# Altium schematic sheet Counter_Switch.SchDoc
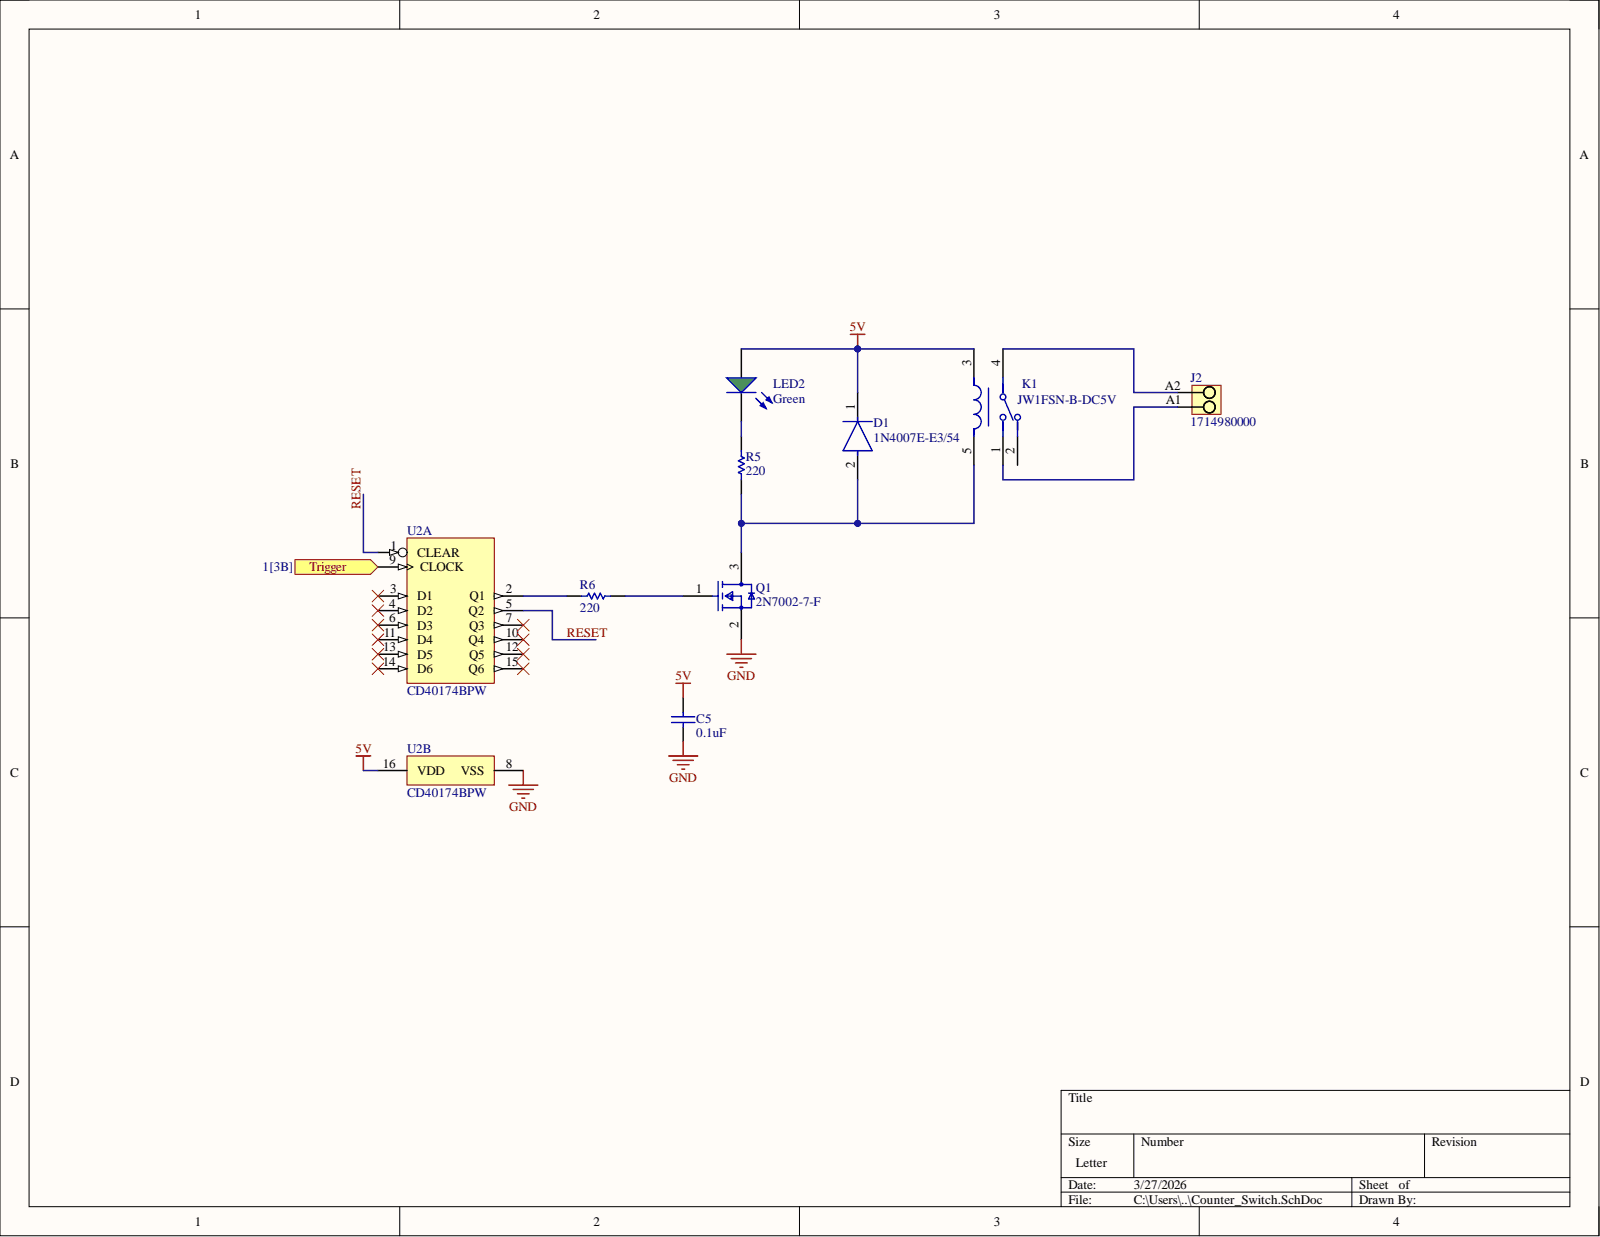
[diagram p0: Counter_Switch.SchDoc]

## Parts
C5  0.1uF  [CAPC2013X145X50ML20T20]
D1  1N4007E-E3/54  [FP-1N4007E-E3-54-MFG]
J2  1714980000  [LM_3_5_135_02]
K1  JW1FSN-B-DC5V  [FP-JW1FSN-B-DC5V-MFG]
LED2  Green  [KING-WP7113SGD_V]
Q1  2N7002-7-F  [FP-SOT23-IPC_A]
R5  220  [RESC2013X60X30NL20T20]
R6  220  [RESC2013X60X30NL20T20]
U2  CD40174BPW  [PW0016A_M]  (parts A,B)

## Ports
Trigger

## Nets
5V: C5.2 D1.1 K1.3 LED2.1 U2.16(VDD)
GND: C5.1 Q1.2 U2.8(VSS)
RESET: U2.1(CLEAR) U2.5(Q2)
Trigger: U2.9(CLOCK) ; port Trigger
NetD1_2: D1.2 K1.5 Q1.3 R5.1
NetJ2_A1: J2.A1 K1.1
NetJ2_A2: J2.A2 K1.4
NetLED2_2: LED2.2 R5.2
NetQ1_1: Q1.1 R6.2
NetR6_1: R6.1 U2.2(Q1)

Not connected: K1 2; U2 3(D1) 4(D2) 6(D3) 7(Q3) 10(Q4) 11(D4) 12(Q5) 13(D5) 14(D6) 15(Q6)

## Library data of the parts
C5 = CAP 0.1uF 10V 0805: Manufacturer: Vitramon; Part Number: VJ0805Y104MXQCW1BC; Component Kind: Standard; Library Name: MDL.SchLib
D1 = Diode General Purpose 1N4007: DIODE GEN PURP 1KV 1A DO204AL; Element Configuration: Single; Forward Voltage: 1.1V; Max Reverse Current: 5uA; Max Surge Current: 45A; Average Rectified Current: 1A; Case/Package: DO-41; Component Kind: Standard; Contact Plating: Tin; Max Operating Temperature: 150°C; Max Repetitive Reverse Voltage (Vrrm): 1kV; Max Reverse Voltage (DC): 1kV; Min Operating Temperature: -50°C; Mount: Through Hole; Number of Pins: 2; Package Quantity: 5500; Packaging: Tape and Reel; Peak Non-Repetitive Surge Current: 45A; Radiation Hardening: No; Reverse Voltage: 1kV; RoHS Compliant: Yes; Series: -; Library Name: MDL.SchLib
J2 = Terminal Block 2Pos: OMNIMATE Signal - series LM; Manufacturer: Weidmueller; Contact_material: Cu-Leg; Contact_surface: tinned; Insulating_material: PA; Mounting_onto_the_PCB: Solder connection; No_of_poles: 2; Pitch_inch: 0,138 inch; Pitch_mm: 3,5 mm; Rated_current_use_group_B_UL_1059: 10 A; Rated_voltage_for_surge_voltage_class_pollution_degree_II_2: 320 V; Rated_voltage_use_group_B_UL_1059: 300 V; Short_text_for_material: LM 3.50/02/135 3.2SN OR BX; Side_termination_characteristic: closed side; Solder_pin_length_mm: 3,2 mm; Solid_max_H05_07_V_U: 1,5 mm²; Solid_min_H05_07_V_U: 0,5 mm²; UL_94_flammability_rating: V-2; Wire_cross_section_AWG_max_UL_1059: AWG 14; Wire_cross_section_AWG_min_UL_1059: AWG 28; Colour: Orange; Component Kind: Standard; ComponentLink1Description: Manufacturer URL; ComponentLink1URL: http://www.weidmueller.com/; ComponentLink2Description: URL Link1; ComponentLink2URL: http://catalog.weidmueller.com/catalog/Start.do?localeId=en&ObjectID=1714980000; PackageReference: LM_3_5_135_02; Packaging: Box; PartNumber: 1714980000; Qty: 100 PCS; Revision: V1; Library Name: MDL.SchLib
K1 = RELAY SPDT 5V: RELAY GEN PURPOSE SPDT 10A 5V; Coil Current: 106mA; Coil Power: 530mW; Coil Resistance: 47R; Coil Type: Non-Latching; Max Voltage Rating (AC): 250V; Max Voltage Rating (DC): 30V; Operate Time: 15ms; Ratings: IP67; Relay Type: General Purpose; Release Time: 5ms; Switching Capacity: 300W; Test Voltage: 5kV; Coil Voltage (DC): 5V; Component Kind: Standard; Contact Current Rating: 10A; Contact Material: Silver; Depth: 12.8mm; Lead Free: Lead Free; Length: 28.6mm; Max Current Rating: 10A; Max Operating Temperature: 85°C; Min Operating Temperature: -40°C; Mount: Through Hole; Number of Pins: 5; Package Quantity: 100; Packaging: Bulk; Power Consumption: 530mW; Radiation Hardening: No; RoHS Compliant: Yes; Series: JW; Switching Current: 10A; Termination: PC Pin; Throw Configuration: SPDT; Voltage Rating (AC): 250V; Voltage Rating (DC): 30V; Library Name: MDL.SchLib
LED2 = LED 5mm Green: LED Green, 62.5 mW, 25 mA, -40 to 85 degC, 2-Pin THD, RoHS, Bulk; Manufacturer: Kingbright; Dominant Wavelength: 568nm; Forward Voltage: 2.2V; Lens Style: Tinted; Luminous Intensity: 40mcd; Test Current: 20mA; Viewing Angle: 30degrees; Case/Package: Radial; Colour: Green; Component Kind: Standard; Datasheet URL: http://www.kingbrightusa.com/images/catalog/SPEC/WP7113SGD.pdf; Datasheet Version: Rev. 6B, 01/2015; Height: 8.6mm; Illumination Colour: Green; Lead Free: LeadFree; Package Description: 2-Pin Through Hole Device, Dia 5.9 mm, Body Length 8.6 mm; Package Quantity: 1000; Package Reference: WP7113SGD; Packaging: Bulk; RoHSCompliant: true; Wavelength: 568nm; Library Name: MDL.SchLib
Q1 = MOSFET 2N7002 SMD: MOSFET N-CH 60V 115MA SOT23-3; Drain to Source Breakdown Voltage: 70V; Drain to Source Resistance: 7.5R; Element Configuration: Single; Fall Time: 5.6ns; Gate to Source Voltage (Vgs): 20V; Input Capacitance: 50pF; Max Power Dissipation: 300mW; Nominal Vgs: 2.5V; Number of Channels: 1; Power Dissipation: 540mW; Rise Time: 3ns; Turn-Off Delay Time: 11ns; Turn-On Delay Time: 7ns; Case/Package: SOT-23; Component Kind: Standard; Contact Plating: Tin; Continuous Drain Current (ID): 115mA; Current Rating: 115mA; Drain to Source Voltage (Vdss): 60V; Dual Supply Voltage: 60V; Height: 1mm; Lead Free: Lead Free; Length: 2.9mm; Max Operating Temperature: 150°C; Min Operating Temperature: -55°C; Mount: Surface Mount; Number of Elements: 1; Number of Pins: 3; On-State Resistance: 7.5R; Package Quantity: 3000; Packaging: Tape and Reel; Radiation Hardening: No; Rds On Max: 7.5R; REACH SVHC: No SVHC; Termination: SMD/SMT; Threshold Voltage: 2.5V; Voltage: 60V; Voltage Rating (DC): 60V; Weight: 0.000282oz; Width: 1.3mm; Library Name: MDL.SchLib
R5 R6 = Res 220 Ohm 0.25W SMD: Manufacturer: KOA Speer; Part Number: SG73S2ATTD2200F; Component Kind: Standard; Library Name: MDL.SchLib
U2 = IC CD4071: CMOS Hex D-Type Flip-Flop, PW0016A, TUBE; Manufacturer: Texas Instruments; 3-State Output: No; Bits(#): 6; F @ Nom Voltage(Max)(Mhz): 8; ICC @ Nom Voltage(Max)(mA): 0.06; Output Drive (IOL/IOH)(Max)(mA): 1.5/-1.5; Output Logic: Non-inverted; Rating: Catalog; Technology Family: CD4000; tpd @ Nom Voltage(Max)(ns): 140; VCC(Max)(V): 18; VCC(Min)(V): 3; Voltage(Nom)(V): 10; Code_JEDEC: MO-153; Component Kind: Standard; DatasheetVersion: SCHS104C; Generic Part Number: CD40174B; Input Type: CMOS; Operating Temperature Range(C): -55 to 125; Output Type: CMOS; PackageReference: PW0016A; Schmitt Trigger: No; Library Name: MDL.SchLib
